# Altium schematic sheet SCM20260D-Power-Special-Pins.SchDoc
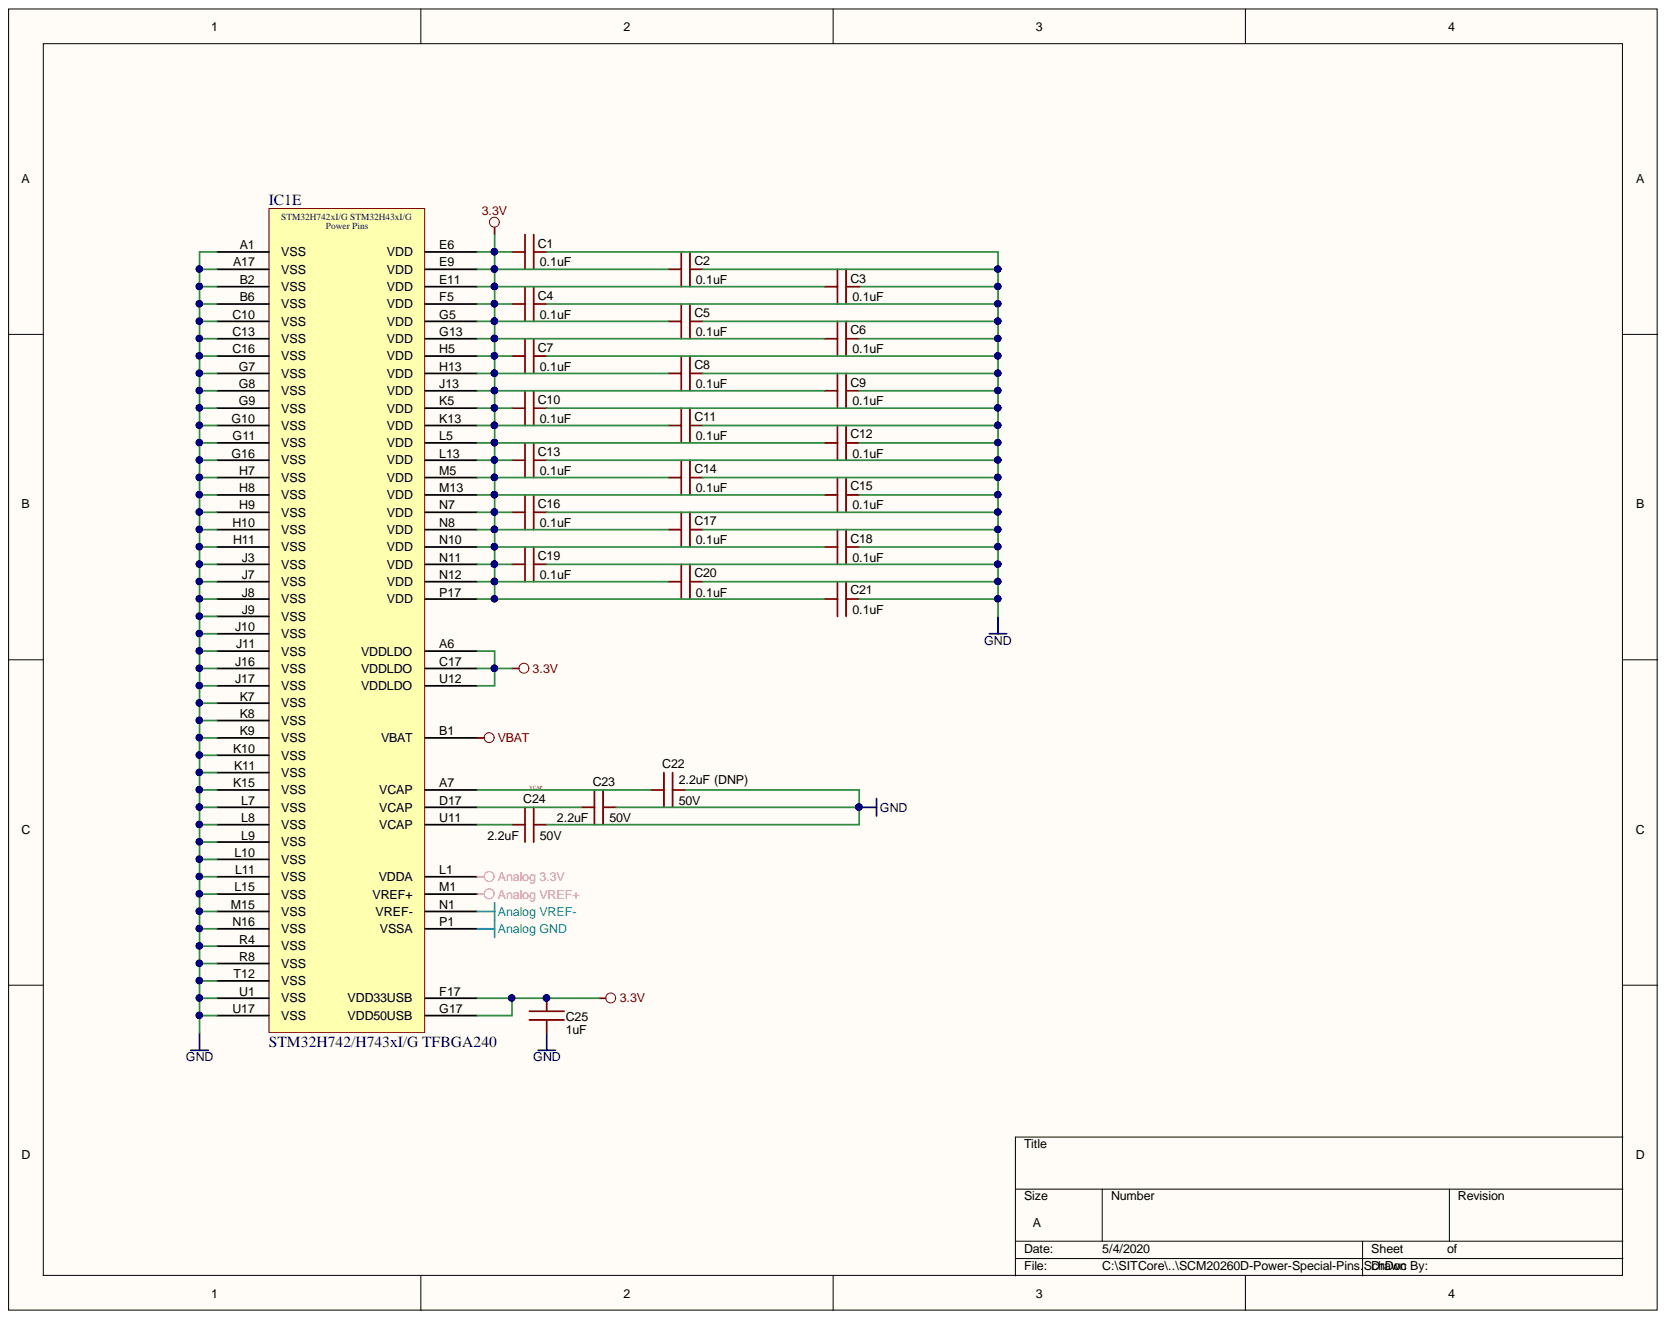
[diagram p0: SCM20260D-Power-Special-Pins.SchDoc]

## Parts
C1  value 0.1uF  Capacitance: 0.1uF  [C0402]  (hidden comment: 0402 Cap 0.1uF)
C2  value 0.1uF  Capacitance: 0.1uF  [C0402]  (hidden comment: 0402 Cap 0.1uF)
C3  value 0.1uF  Capacitance: 0.1uF  [C0402]  (hidden comment: 0402 Cap 0.1uF)
C4  value 0.1uF  Capacitance: 0.1uF  [C0402]  (hidden comment: 0402 Cap 0.1uF)
C5  value 0.1uF  Capacitance: 0.1uF  [C0402]  (hidden comment: 0402 Cap 0.1uF)
C6  value 0.1uF  Capacitance: 0.1uF  [C0402]  (hidden comment: 0402 Cap 0.1uF)
C7  value 0.1uF  Capacitance: 0.1uF  [C0402]  (hidden comment: 0402 Cap 0.1uF)
C8  value 0.1uF  Capacitance: 0.1uF  [C0402]  (hidden comment: 0402 Cap 0.1uF)
C9  value 0.1uF  Capacitance: 0.1uF  [C0402]  (hidden comment: 0402 Cap 0.1uF)
C10  value 0.1uF  Capacitance: 0.1uF  [C0402]  (hidden comment: 0402 Cap 0.1uF)
C11  value 0.1uF  Capacitance: 0.1uF  [C0402]  (hidden comment: 0402 Cap 0.1uF)
C12  value 0.1uF  Capacitance: 0.1uF  [C0402]  (hidden comment: 0402 Cap 0.1uF)
C13  value 0.1uF  Capacitance: 0.1uF  [C0402]  (hidden comment: 0402 Cap 0.1uF)
C14  value 0.1uF  Capacitance: 0.1uF  [C0402]  (hidden comment: 0402 Cap 0.1uF)
C15  value 0.1uF  Capacitance: 0.1uF  [C0402]  (hidden comment: 0402 Cap 0.1uF)
C16  value 0.1uF  Capacitance: 0.1uF  [C0402]  (hidden comment: 0402 Cap 0.1uF)
C17  value 0.1uF  Capacitance: 0.1uF  [C0402]  (hidden comment: 0402 Cap 0.1uF)
C18  value 0.1uF  Capacitance: 0.1uF  [C0402]  (hidden comment: 0402 Cap 0.1uF)
C19  value 0.1uF  Capacitance: 0.1uF  [C0402]  (hidden comment: 0402 Cap 0.1uF)
C20  value 0.1uF  Capacitance: 0.1uF  [C0402]  (hidden comment: 0402 Cap 0.1uF)
C21  value 0.1uF  Capacitance: 0.1uF  [C0402]  (hidden comment: 0402 Cap 0.1uF)
C22  value 2.2uF (DNP)  Capacitance: 2.2uF (DNP)  Voltage: 50V  [C0805]  (hidden comment: 0805 Cap 2.2uF)
C23  value 2.2uF  Capacitance: 2.2uF  Voltage: 50V  [C0805]  (hidden comment: 0805 Cap 2.2uF)
C24  value 2.2uF  Capacitance: 2.2uF  Voltage: 50V  [C0805]  (hidden comment: 0805 Cap 2.2uF)
C25  value 1uF  Capacitance: 1uF  [C0402]  (hidden comment: 0402 Cap 1uF)
IC1  STM32H742/H743xI/G TFBGA240  [TFBGA240+25]  (part E)

## Nets
3.3V: C1.1 C2.1 C3.1 C4.1 C5.1 C6.1 C7.1 C8.1 C9.1 C10.1 C11.1 C12.1 C13.1 C14.1 C15.1 C16.1 C17.1 C18.1 C19.1 C20.1 C21.1 C25.2 IC1.A6(VDDLDO) IC1.C17(VDDLDO) IC1.E6(VDD) IC1.E9(VDD) IC1.E11(VDD) IC1.F5(VDD) IC1.F17(VDD33USB) IC1.G5(VDD) IC1.G13(VDD) IC1.G17(VDD50USB) IC1.H5(VDD) IC1.H13(VDD) IC1.J13(VDD) IC1.K5(VDD) IC1.K13(VDD) IC1.L5(VDD) IC1.L13(VDD) IC1.M5(VDD) IC1.M13(VDD) IC1.N7(VDD) IC1.N8(VDD) IC1.N10(VDD) IC1.N11(VDD) IC1.N12(VDD) IC1.P17(VDD) IC1.U12(VDDLDO)
Analog 3.3V: IC1.L1(VDDA)
Analog GND: IC1.P1(VSSA)
Analog VREF+: IC1.M1(VREF+)
Analog VREF-: IC1.N1(VREF-)
GND: C1.2 C2.2 C3.2 C4.2 C5.2 C6.2 C7.2 C8.2 C9.2 C10.2 C11.2 C12.2 C13.2 C14.2 C15.2 C16.2 C17.2 C18.2 C19.2 C20.2 C21.2 C22.2 C23.2 C24.2 C25.1 IC1.A1(VSS) IC1.A17(VSS) IC1.B2(VSS) IC1.B6(VSS) IC1.C10(VSS) IC1.C13(VSS) IC1.C16(VSS) IC1.G7(VSS) IC1.G8(VSS) IC1.G9(VSS) IC1.G10(VSS) IC1.G11(VSS) IC1.G16(VSS) IC1.H7(VSS) IC1.H8(VSS) IC1.H9(VSS) IC1.H10(VSS) IC1.H11(VSS) IC1.J3(VSS) IC1.J7(VSS) IC1.J8(VSS) IC1.J9(VSS) IC1.J10(VSS) IC1.J11(VSS) IC1.J16(VSS) IC1.J17(VSS) IC1.K7(VSS) IC1.K8(VSS) IC1.K9(VSS) IC1.K10(VSS) IC1.K11(VSS) IC1.K15(VSS) IC1.L7(VSS) IC1.L8(VSS) IC1.L9(VSS) IC1.L10(VSS) IC1.L11(VSS) IC1.L15(VSS) IC1.M15(VSS) IC1.N16(VSS) IC1.R4(VSS) IC1.R8(VSS) IC1.T12(VSS) IC1.U1(VSS) IC1.U17(VSS)
VBAT: IC1.B1(VBAT)
VCAP: C22.1 IC1.A7(VCAP)
NetC23_1: C23.1 IC1.D17(VCAP)
NetC24_1: C24.1 IC1.U11(VCAP)

## Library data of the parts
C1-C21 = 0402 Cap 0.1uF: 0.1uF ±10% 16V X7R 0402 Multilayer Ceramic Capacitors MLCC - SMD/SMT RoHS; Mfr.Part #: CC0402KRX7R7BB104; Category: Ceramic; Component Kind: Standard; LCSC Part #: C60474; Package: 0402; Tolerance: ±10%; Voltage: 16V; Library Name: GHI-Passives.SchLib
C22-C24 = 0805 Cap 2.2uF: 2.2uF ±10% 50V X5R 0805 Multilayer Ceramic Capacitors MLCC - SMD/SMT RoHS; Mfr.Part #: CL21A225KBQNNNE; Category: Ceramic; Component Kind: Standard; LCSC Part #: C377773; Package: 0805; Tolerance: ±10%; Library Name: GHI-Passives.SchLib
C25 = 0402 Cap 1uF: 1uF ±10% 6.3V X5R 0402 Multilayer Ceramic Capacitors MLCC - SMD/SMT RoHS; Manufacturer: Samsung Electro-Mechanics; Mfr.Part #: CL05A105KQ5NNNC; Category: Ceramic; Component Kind: Standard; LCSC Part #: C107372; Package: 0402; Tolerance: ±10%; Voltage: 6.3V; Library Name: GHI-Passives.SchLib
IC1 = STM32H742/H743xI/G TFBGA240+25: STM32H742/H743xI/G TFBGA240+25 (14 x 14mm); Component Kind: Standard; Library Name: GHI Processors.SchLib
